# Altium schematic sheet llc_voltage_sensor.SchDoc
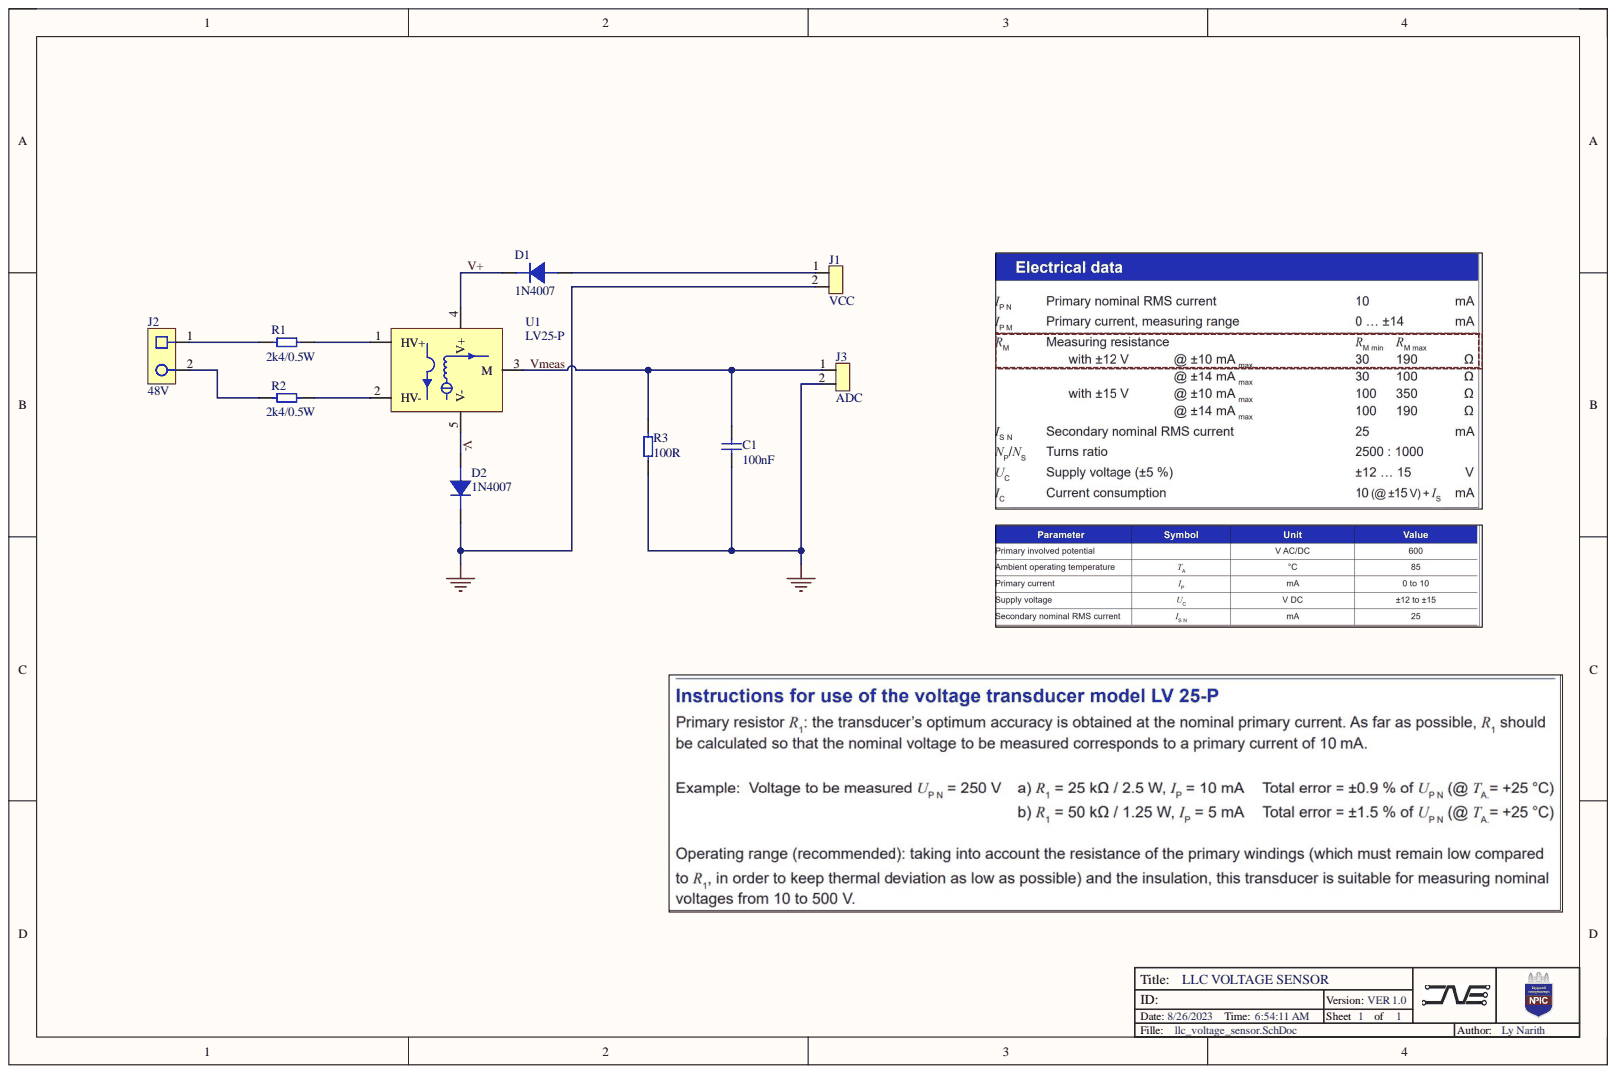
[diagram p0: llc_voltage_sensor.SchDoc]

## Parts
C1  100nF  [C_DISC_D7.5mm_W2.5mm_P5.00mm - duplicate]
D1  1N4007  [DIODE_1N400x]
D2  1N4007  [DIODE_1N400x]
J1  VCC  [JST_XH_B2B-XH-A_1x02_P2.50mm_Vertical]
J2  48V  [KF7.62_Screw_Terminal]
J3  ADC  [JST_XH_B2B-XH-A_1x02_P2.50mm_Vertical]
R1  2k4/0.5W  [Axial R_Axial_DIN0309_L9.0mm_D3.2mm_P12.70mm_Horizontal]
R2  2k4/0.5W  [Axial R_Axial_DIN0309_L9.0mm_D3.2mm_P12.70mm_Horizontal]
R3  100R  [RES_0.25W_P7.62]
U1  LV25-P  [LEM_LV25-P]

## Nets
GND: C1.1 D2.1 J1.2 J3.2 R3.1
V+: D1.1 U1.4(V+)
V-: D2.2 U1.5(V-)
Vmeas: C1.2 J3.1 R3.2 U1.3(M)
NetD1_2: D1.2 J1.1
NetJ2_1: J2.1 R1.1
NetJ2_2: J2.2 R2.1
NetR1_2: R1.2 U1.1(HV+)
NetR2_2: R2.2 U1.2(HV-)

## Library data of the parts
C1 = CAP_100nF: Component Kind: Standard; Library Name: Schematic-Lib_Narith.SchLib
D1 D2 = DIODE_1N400x: Component Kind: Standard; Library Name: Schematic-Lib_Narith.SchLib
J1 J3 = CONN_01x02_JST_XH_P2.50mm: Component Kind: Standard; Library Name: Schematic-Lib_Narith.SchLib
J2 = SCREW_TERMINAL: Component Kind: Standard; Library Name: Schematic-Lib_Narith.SchLib
R1-R3 = RES_P7.62_0.24W_1: Component Kind: Standard; Library Name: Schematic-Lib_Narith.SchLib
U1 = LV25-P: Voltage Transducer LV 25-P; Component Kind: Standard; Library Name: Schematic-Lib_Narith.SchLib
